# Altium schematic sheet Boost_Converter.SchDoc
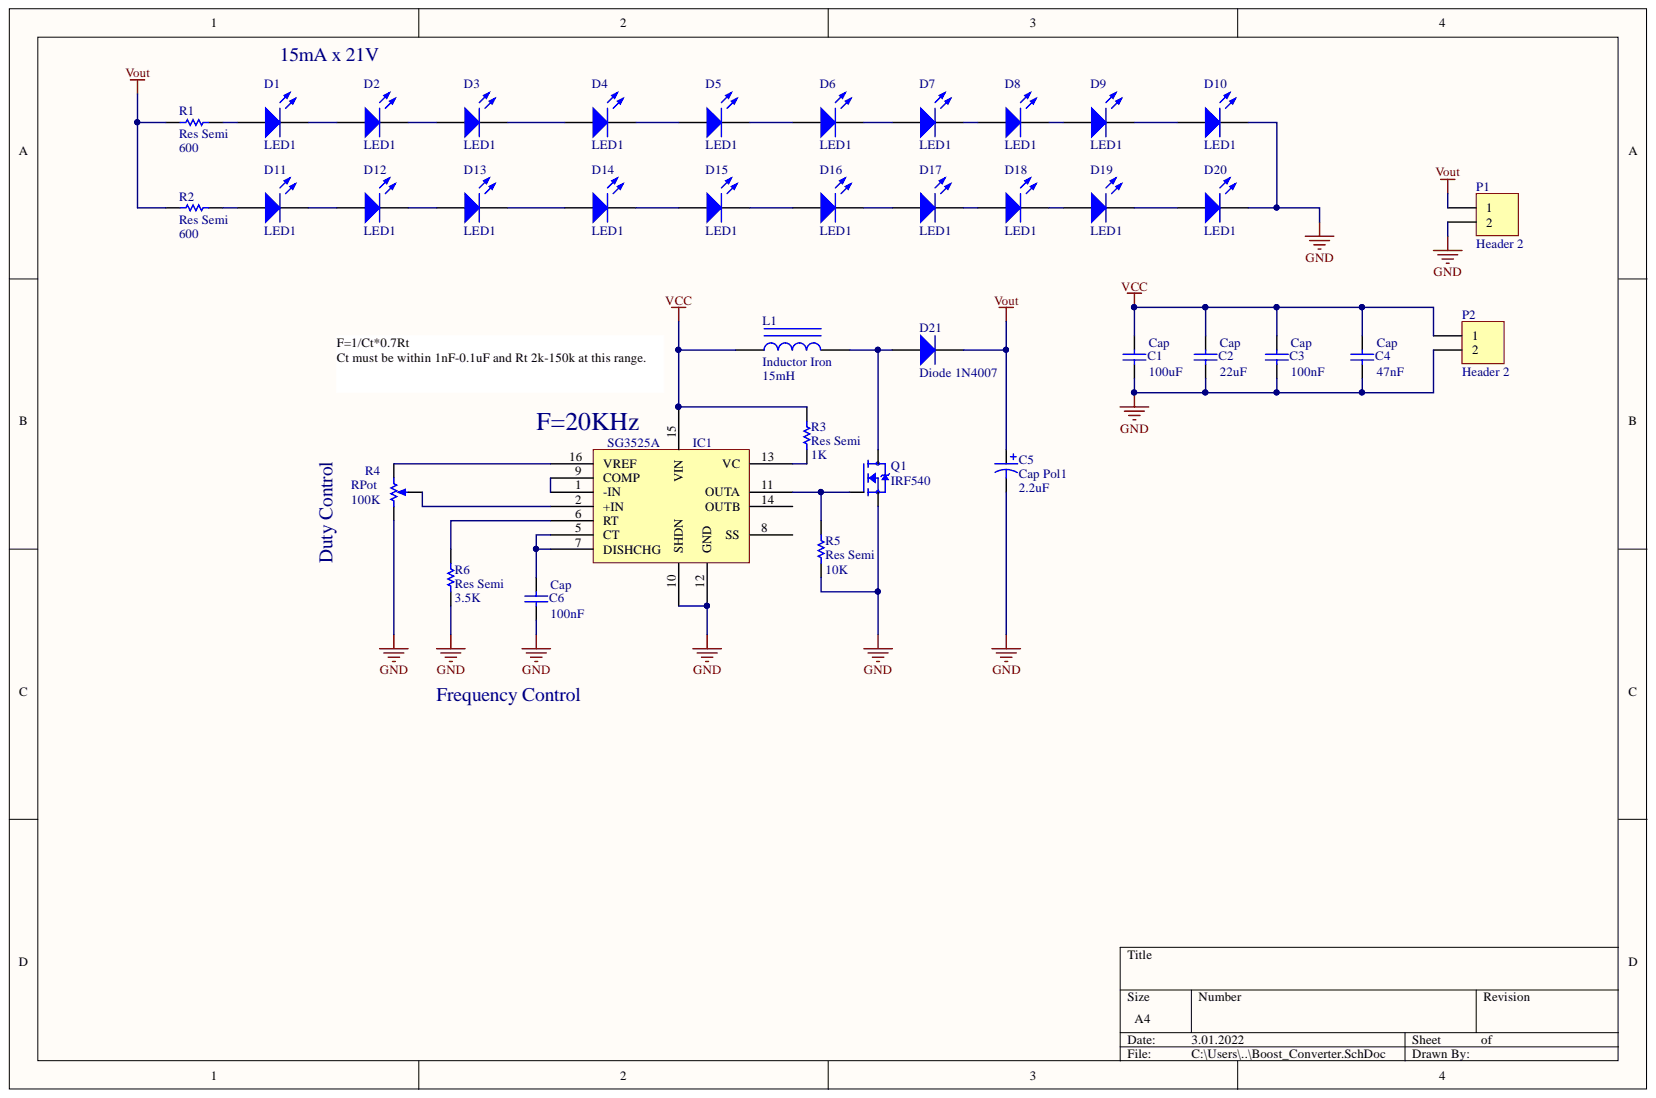
[diagram p0: Boost_Converter.SchDoc]

## Parts
C1  Cap  value 100uF  [RAD-0.3]
C2  Cap  value 22uF  [RAD-0.3]
C3  Cap  value 100nF  [RAD-0.3]
C4  Cap  value 47nF  [RAD-0.3]
C5  Cap Pol1  value 2.2uF  [RB7.6-15]
C6  Cap  value 100nF  [RAD-0.3]
D1  LED1  [LED-1]
D2  LED1  [LED-1]
D3  LED1  [LED-1]
D4  LED1  [LED-1]
D5  LED1  [LED-1]
D6  LED1  [LED-1]
D7  LED1  [LED-1]
D8  LED1  [LED-1]
D9  LED1  [LED-1]
D10  LED1  [LED-1]
D11  LED1  [LED-1]
D12  LED1  [LED-1]
D13  LED1  [LED-1]
D14  LED1  [LED-1]
D15  LED1  [LED-1]
D16  LED1  [LED-1]
D17  LED1  [LED-1]
D18  LED1  [LED-1]
D19  LED1  [LED-1]
D20  LED1  [LED-1]
D21  Diode 1N4007  [DO-41]
IC1  SG3525A  [SG3525A]
L1  Inductor Iron  value 15mH  [AXIAL-0.9]
P1  Header 2  [HDR1X2]
P2  Header 2  [HDR1X2]
Q1  IRF540  [TO254P1052X465X1989-3]
R1  Res Semi  value 600  [AXIAL-0.5]
R2  Res Semi  value 600  [AXIAL-0.5]
R3  Res Semi  value 1K  [AXIAL-0.5]
R4  RPot  value 100K  [VR5]
R5  Res Semi  value 10K  [AXIAL-0.5]
R6  Res Semi  value 3.5K  [AXIAL-0.5]

## Nets
GND: C1.1 C2.1 C3.1 C4.1 C5.2 C6.1 D10.2 D20.2 IC1.10(SHDN) IC1.12(GND) P1.2 P2.2 Q1.3 R4.1 R5.1 R6.1
VCC: C1.2 C2.2 C3.2 C4.2 IC1.15(VIN) L1.1 P2.1 R3.2
Vout: C5.1 D21.2 P1.1 R1.2 R2.2
NetC6_2: C6.2 IC1.5(CT) IC1.7(DISHCHG)
NetD1_1: D1.1 R1.1
NetD1_2: D1.2 D2.1
NetD2_2: D2.2 D3.1
NetD3_2: D3.2 D4.1
NetD4_2: D4.2 D5.1
NetD5_2: D5.2 D6.1
NetD6_2: D6.2 D7.1
NetD7_2: D7.2 D8.1
NetD8_2: D8.2 D9.1
NetD9_2: D9.2 D10.1
NetD11_1: D11.1 R2.1
NetD11_2: D11.2 D12.1
NetD12_2: D12.2 D13.1
NetD13_2: D13.2 D14.1
NetD14_2: D14.2 D15.1
NetD15_2: D15.2 D16.1
NetD16_2: D16.2 D17.1
NetD17_2: D17.2 D18.1
NetD18_2: D18.2 D19.1
NetD19_2: D19.2 D20.1
NetD21_1: D21.1 L1.2 Q1.2
NetIC1_1: IC1.1(-IN) IC1.9(COMP)
NetIC1_2: IC1.2(+IN) R4.3
NetIC1_6: IC1.6(RT) R6.2
NetIC1_11: IC1.11(OUTA) Q1.1 R5.2
NetIC1_13: IC1.13(VC) R3.1
NetIC1_16: IC1.16(VREF) R4.2

Not connected: IC1 8(SS) 14(OUTB)

## Library data of the parts
C1-C4 C6 = Cap: Capacitor; Component Kind: Standard; LatestRevisionDate: 17-Jul-2002; LatestRevisionNote: Re-released for DXP Platform.; Library Name: Miscellaneous Devices.IntLib; PackageDescription: Radial Cap, Thru-Hole; 2 Leads; 0.3 in Pin Spacing; PackageReference: RAD-0.3; Published: 8-Jun-2000; Signal Integrity: Cap; Simulation: CAP; Publisher: Altium Limited
C5 = Cap Pol1: Polarized Capacitor (Radial); Component Kind: Standard; LatestRevisionDate: 17-Jul-2002; LatestRevisionNote: Re-released for DXP Platform.; Library Name: Miscellaneous Devices.IntLib; PackageDescription: Polarized Capacitor (Radial); 2 Leads; PackageReference: RB7.6-15; Published: 8-Jun-2000; Signal Integrity: Cap Pol; Simulation: CAP; Publisher: Altium Limited
D1-D20 = LED1: Typical RED GaAs LED; Component Kind: Standard; LatestRevisionDate: 08-Jul-2005; LatestRevisionNote: Mech Layer 1 removed.; Library Name: Miscellaneous Devices.IntLib; PackageDescription: LED; 2 Leads; PackageReference: LED-1; Published: 8-Jun-2000; Simulation: LED1; Publisher: Altium Limited
D21 = Diode 1N4007: 1 Amp General Purpose Rectifier; Code_JEDEC: DO-204-AL; Component Kind: Standard; ComponentLink1Description: Manufacturer Link; ComponentLink1URL: http://www.fairchildsemi.com/; ComponentLink2Description: Datasheet; ComponentLink2URL: http://www.fairchildsemi.com/ds/1N%2F1N4001.pdf; DatasheetVersion: 1998; LatestRevisionDate: 17-Jul-2002; LatestRevisionNote: Re-released for DXP Platform.; Library Name: Miscellaneous Devices.IntLib; PackageDescription: Diode, Axial; Body 5.2 x 2.7 mm (LxDia. max), Lead Dia. 0.86 mm (max); PackageReference: DO-41; PackageVersion: Aug-1999; Published: 8-Jun-2000; Signal Integrity: 1N4007; Simulation: 1N4007; Publisher: Altium Limited
IC1 = SG3525A: PWM GENERATOR IC; Component Kind: Standard; Library Name: Boost_Shematic_Lib.SchLib
L1 = Inductor Iron: Magnetic-Core Inductor; Component Kind: Standard; LatestRevisionDate: 17-Jul-2002; LatestRevisionNote: Re-released for DXP Platform.; Library Name: Miscellaneous Devices.IntLib; PackageDescription: Axial Device, Thru-Hole; 2 Leads; 0.9 in Pin Spacing; PackageReference: AXIAL-0.9; Published: 24-Mar-1999; Signal Integrity: Inductor Iron; Simulation: INDUCTOR; Publisher: Altium Limited
P1 P2 = Header 2: Header, 2-Pin; Component Kind: Standard; LatestRevisionDate: 17-Jul-2002; LatestRevisionNote: Re-released for DXP Platform.; Library Name: Miscellaneous Connectors.IntLib; Signal Integrity: Connector; Publisher: Altium Limited
Q1 = IRF540: N-Channel 100V 22A _Tc_ 85W _Tc_ Through Hole TO-220AB; MF: Vishay; Availability: Unavailable; Component Kind: Standard; Library Name: IRF540_mosfet.IntLib; MP: IRF540; Package: TO-220-3 Samsung; Price: None; SnapEDA_Link: https://www.snapeda.com/parts/IRF540/Vishay%20Siliconix/view-part/1024526/?ref=snap
R1-R3 R5 R6 = Res Semi: Semiconductor Resistor; Component Kind: Standard; LatestRevisionDate: 17-Jul-2002; LatestRevisionNote: Re-released for DXP Platform.; Library Name: Miscellaneous Devices.IntLib; PackageDescription: Axial Device, Thru-Hole; 2 Leads; 0.5 in Pin Spacing; PackageReference: AXIAL-0.5; Published: 8-Jun-2000; Signal Integrity: Res Semi; Simulation: RES; Publisher: Altium Limited
R4 = RPot: Potentiometer; Set Position: 0.5; Sim Note: Enter the Resistance value and the set point into parameter fields.; Component Kind: Standard; LatestRevisionDate: 17-Jul-2002; LatestRevisionNote: Re-released for DXP Platform.; Library Name: Miscellaneous Devices.IntLib; PackageDescription: Variable Resistor, Thru-Hole; 3 Leads; PackageReference: VR5; Published: 8-Jun-2000; Simulation: POT; Publisher: Altium Limited
